# Altium schematic sheet MCU.SchDoc(MCU)
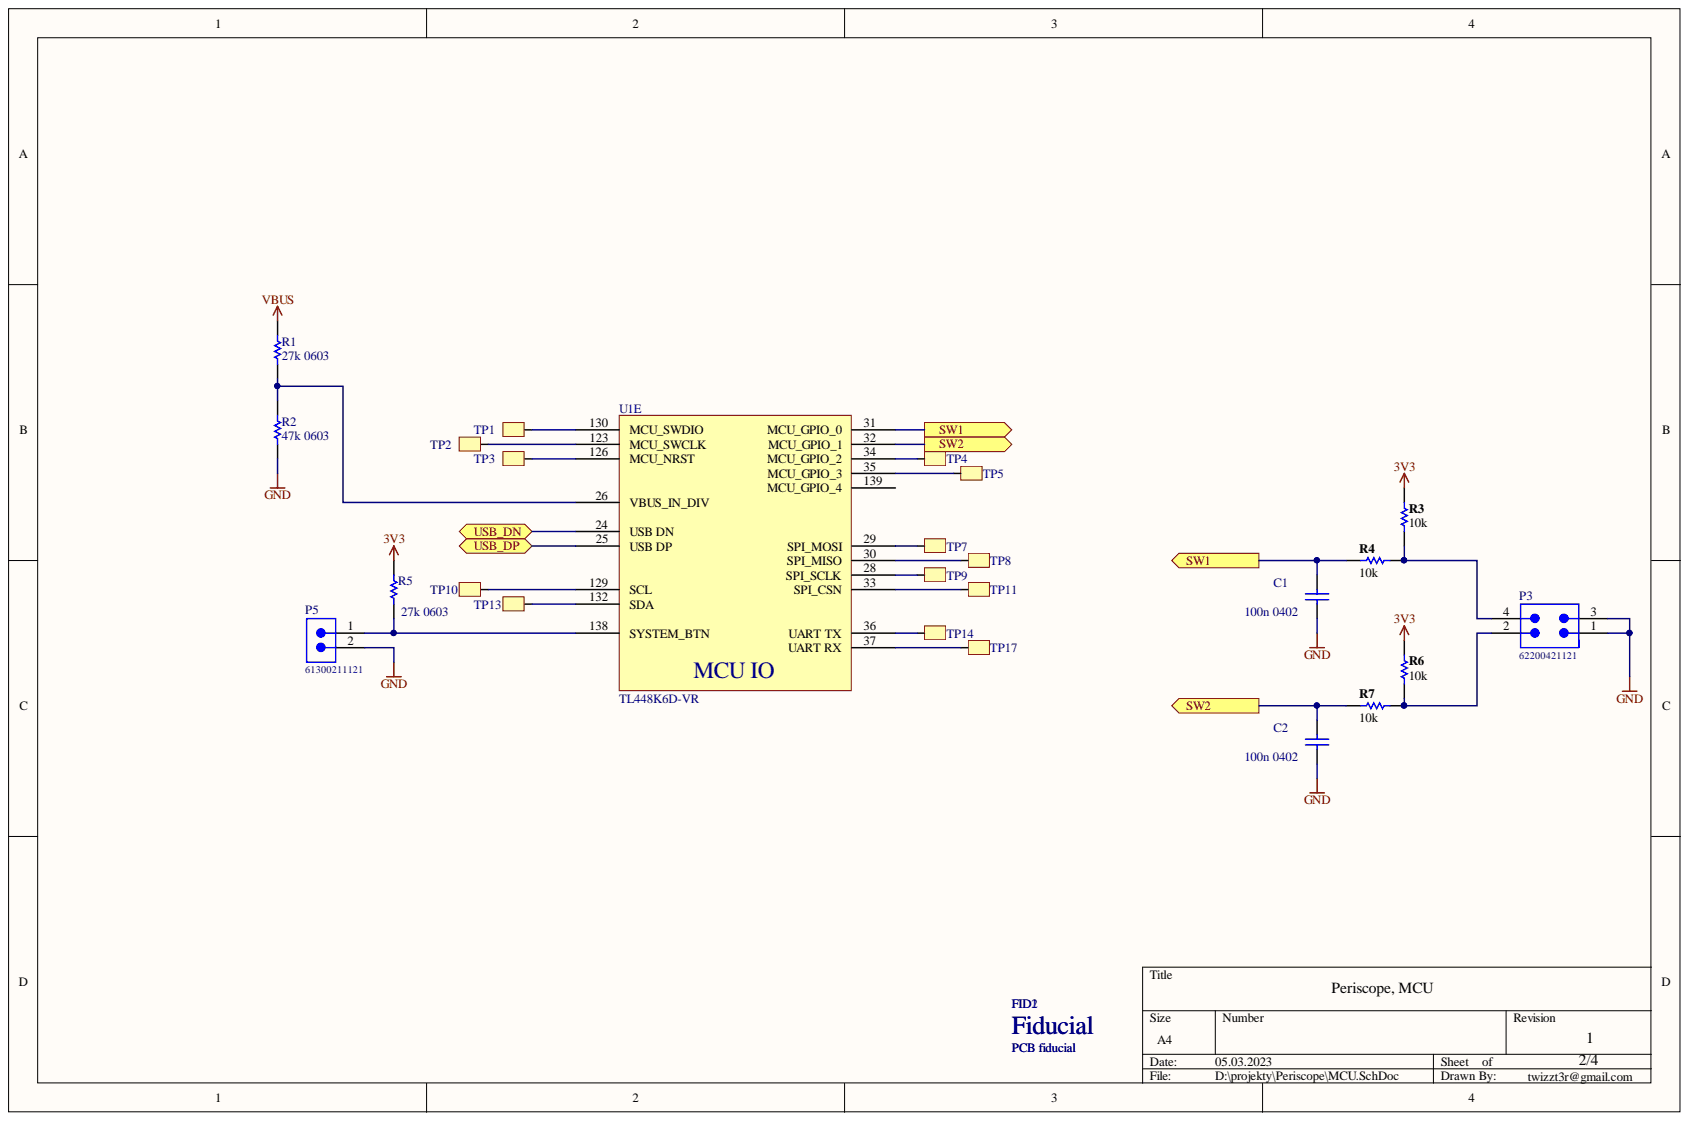
[diagram p0: MCU.SchDoc]

## Parts
C1  100n 0402  [WCAP-CSGP_0402_H=0.5mm]
C2  100n 0402  [WCAP-CSGP_0402_H=0.5mm]
FID1  PCB fiducial  [FIDUCIAL-1MM]  (no BOM)
FID2  PCB fiducial  [FIDUCIAL-1MM]  (no BOM)
P3  62200421121  [62200421121]
P5  61300211121  [61300211121]
R1  27k 0603  [R_0603]
R2  47k 0603  [R_0603]
R3  value 10k  [R_0603]  (hidden comment: 10k 0603)
R4  value 10k  [R_0603]  (hidden comment: 10k 0603)
R5  27k 0603  [R_0603]
R6  value 10k  [R_0603]  (hidden comment: 10k 0603)
R7  value 10k  [R_0603]  (hidden comment: 10k 0603)
TP1  testpoint  [TP_round_1MM]  (value not drawn)
TP2  testpoint  [TP_round_1MM]  (value not drawn)
TP3  testpoint  [TP_round_1MM]  (value not drawn)
TP4  testpoint  [TP_round_1MM]  (value not drawn)
TP5  testpoint  [TP_round_1MM]  (value not drawn)
TP7  testpoint  [TP_round_1MM]  (value not drawn)
TP8  testpoint  [TP_round_1MM]  (value not drawn)
TP9  testpoint  [TP_round_1MM]  (value not drawn)
TP10  testpoint  [TP_round_1MM]  (value not drawn)
TP11  testpoint  [TP_round_1MM]  (value not drawn)
TP13  testpoint  [TP_round_1MM]  (value not drawn)
TP14  testpoint  [TP_round_1MM]  (value not drawn)
TP17  testpoint  [TP_round_1MM]  (value not drawn)
U1  TL448K6D-VR  [TL448K6D-VR]  (part E)

## Ports
SW1, SW2, USB_DN, USB_DP

## Nets
3V3: R3.1 R5.2 R6.1
GND: C1.1 C2.1 P3.1 P3.3 P5.2 R2.1
VBUS: R1.2
SW1: C1.2 R4.2 U1.31(MCU_GPIO_0) ; port SW1
SW2: C2.2 R7.2 U1.32(MCU_GPIO_1) ; port SW2
USB_DN: U1.24(USB_DN) ; port USB_DN
USB_DP: U1.25(USB_DP) ; port USB_DP
NetP3_2: P3.2 R6.2 R7.1
NetP3_4: P3.4 R3.2 R4.1
NetP5_1: P5.1 R5.1 U1.138(SYSTEM_BTN)
NetR1_1: R1.1 R2.2 U1.26(VBUS_IN_DIV)
NetTP1_1: TP1.1 U1.130(MCU_SWDIO)
NetTP2_1: TP2.1 U1.123(MCU_SWCLK)
NetTP3_1: TP3.1 U1.126(MCU_NRST)
NetTP4_1: TP4.1 U1.34(MCU_GPIO_2)
NetTP5_1: TP5.1 U1.35(MCU_GPIO_3)
NetTP7_1: TP7.1 U1.29(SPI_MOSI)
NetTP8_1: TP8.1 U1.30(SPI_MISO)
NetTP9_1: TP9.1 U1.28(SPI_SCLK)
NetTP10_1: TP10.1 U1.129(SCL)
NetTP11_1: TP11.1 U1.33(SPI_CSN)
NetTP13_1: TP13.1 U1.132(SDA)
NetTP14_1: TP14.1 U1.36(UART_TX)
NetTP17_1: TP17.1 U1.37(UART_RX)

Not connected: U1 139(MCU_GPIO_4)

## Library data of the parts
C1 C2 = C_0402: Value: 100n 0402; Library Name: SCH.SchLib; Component Kind: Standard
FID1 FID2 = _FIDUCIAL: Library Name: SCH.SchLib
P3 = 62200421121: WR-PHD Pin Header, THT, pitch 1.27mm, Dual Row, Vertical, 4p; Manufacturer: Wurth Elektronik; Working Voltage: 100VAC; Withstanding Voltage: 500VAC; Rated Current: 1A; Pin Number: 4; Contact Resistance: 20mOhm; Row Number: Dual; Published Date: May 2020; Pitch: 1.27mm; Packaging: Box; Orientation: Vertical; Operating Temperature: -40 to +105degC; Mounting Technology: THT; Manufacturer Part Number: 62200421121; Library Name: SCH.SchLib; Gender: Pin Header; ComponentLink2URL: https://www.we-online.com/catalog/datasheet/62200421121.pdf; ComponentLink2Description: Datasheet; ComponentLink1URL: http://www.we-online.de; ComponentLink1Description: Manufacturer; Component Kind: Standard
P5 = 61300211121: WR-PHD Pin Header, THT, pitch 2.54mm, Single Row, Vertical, 2p; Manufacturer: Wurth Elektronik; Working Voltage: 250VAC; Withstanding Voltage: 500VAC; Rated Current: 3A; Pin Number: 2; Contact Resistance: 20mOhm; Row Number: Single; Published Date: May 2020; Pitch: 2.54mm; Packaging: Bag; Orientation: Vertical; Operating Temperature: -40 to +105degC; Mounting Technology: THT; Manufacturer Part Number: 61300211121; Library Name: SCH.SchLib; Gender: Pin Header; ComponentLink2URL: https://www.we-online.com/catalog/datasheet/61300211121.pdf; ComponentLink2Description: Datasheet; ComponentLink1URL: http://www.we-online.de; ComponentLink1Description: Manufacturer; Component Kind: Standard
R1 R2 R5 = R_0603: Library Name: SCH.SchLib; Component Kind: Standard
R3 R4 R6 R7 = R_0402: 0402 (1005 Metric)  SMD; Tolerance: 5 %; Power: 0.063 W; Case-Metric: 1005; Case-EIA: 0402; Technology: SMT; Library Name: SCH.SchLib; Component Kind: Standard
TP1-TP5 TP7-TP11 TP13 TP14 TP17 = TP: Library Name: SCH.SchLib; Component Kind: Standard
U1 = TL448K6D-VR: Library Name: SCH.SchLib; Component Kind: Standard
